# Altium schematic sheet Debug.SchDoc
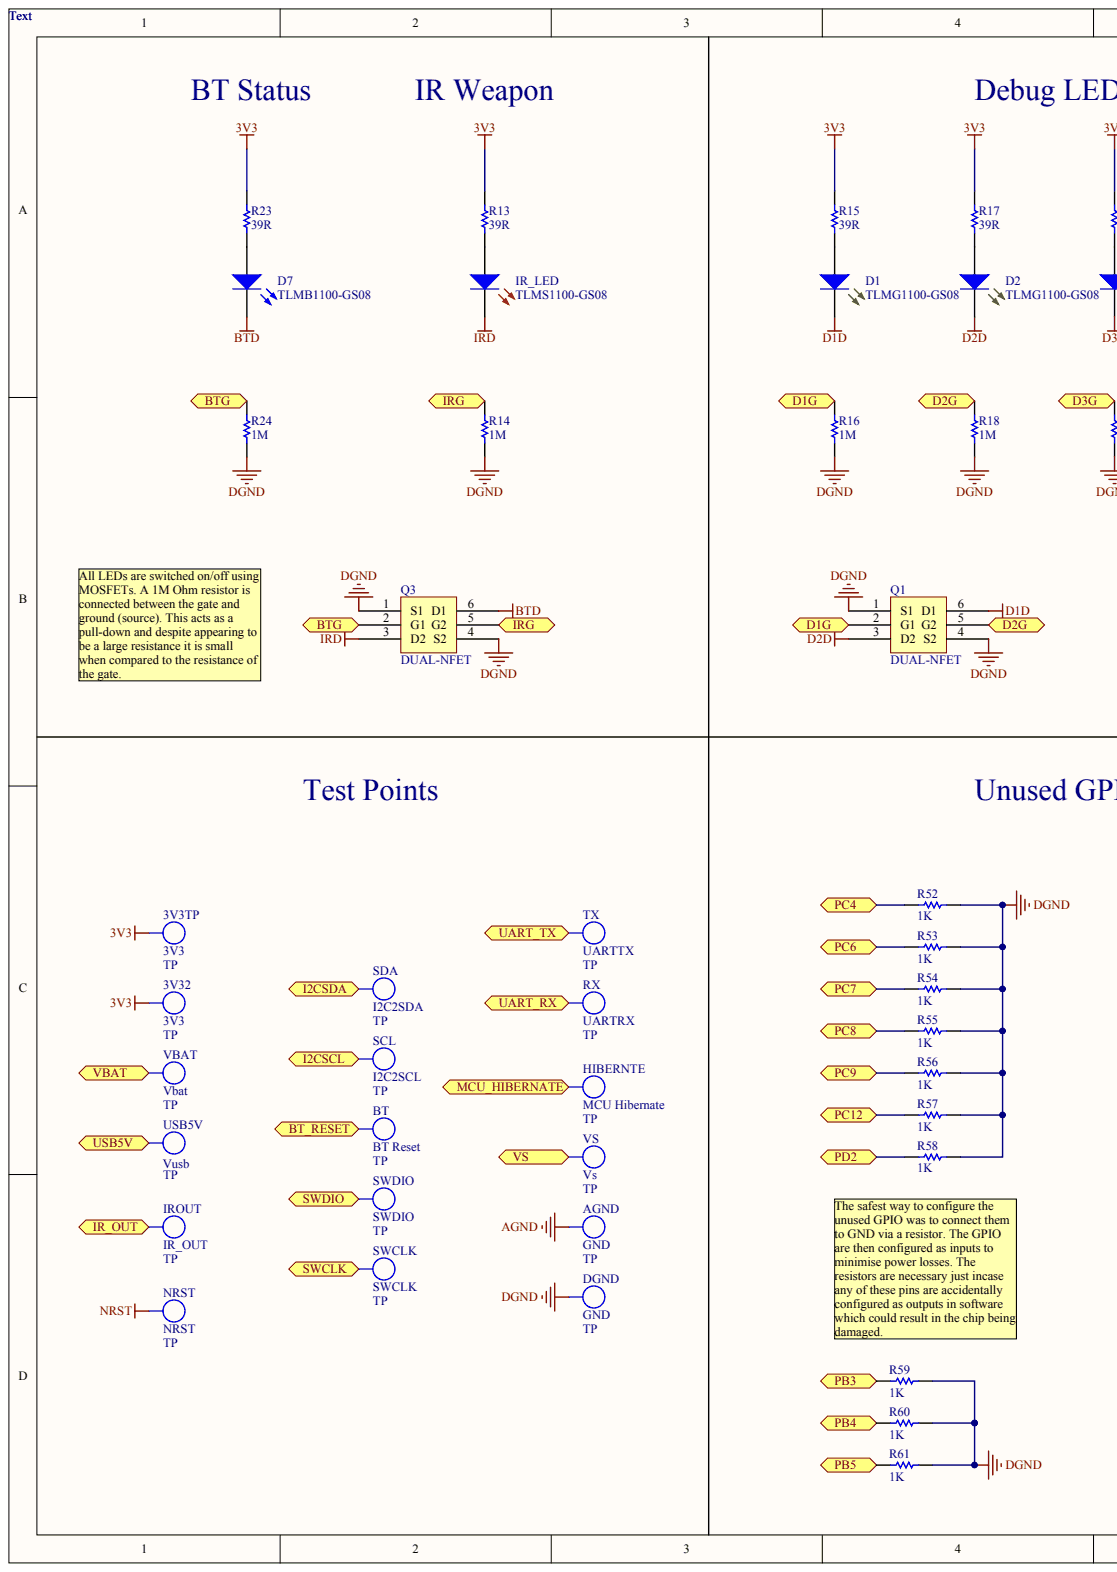
[diagram p0: Debug.SchDoc, left]
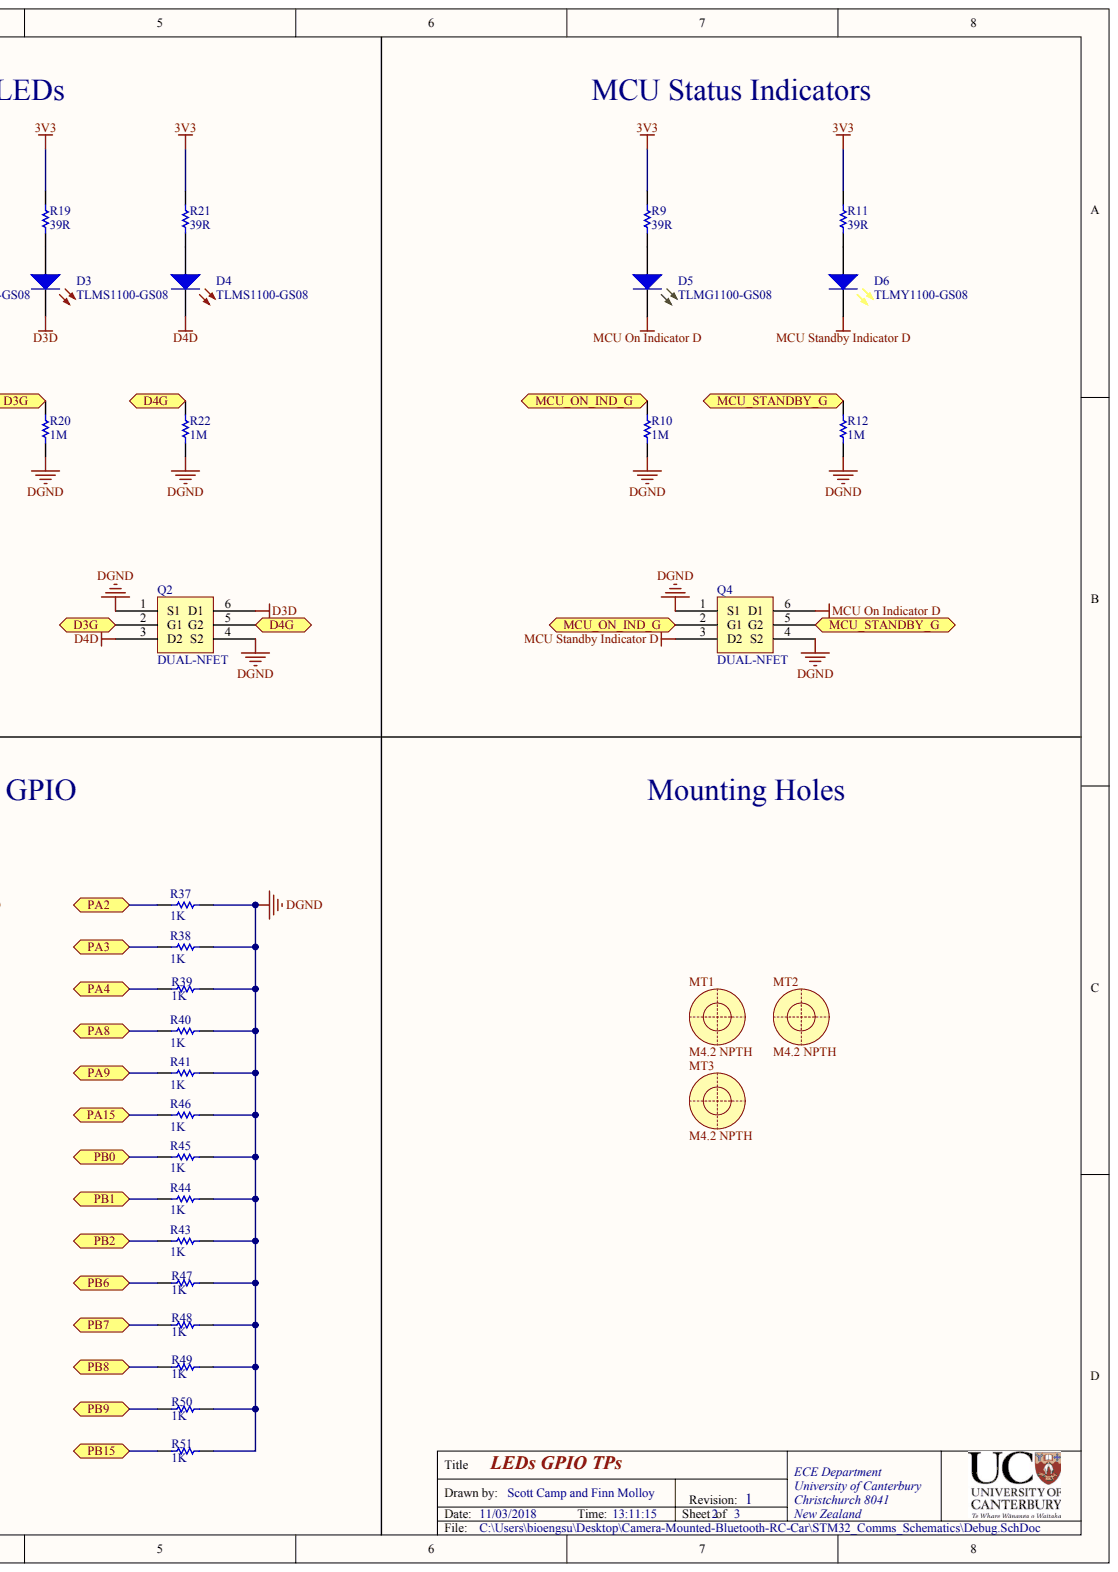
[diagram p1: Debug.SchDoc, right]

## Parts
3V3TP  3V3
3V32  3V3  value TP
AGND  GND  value TP
BT  BT Reset  value TP
D1  TLMG1100-GS08
D2  TLMG1100-GS08
D3  TLMS1100-GS08
D4  TLMS1100-GS08
D5  TLMG1100-GS08
D6  TLMY1100-GS08
D7  TLMB1100-GS08
DGND  GND  value TP
HIBERNTE  MCU Hibernate  value TP
IR_LED  TLMS1100-GS08
IROUT  IR_OUT  value TP
MT1  M4.2 NPTH
MT2  M4.2 NPTH
MT3  M4.2 NPTH
NRST  NRST  value TP
Q1  DUAL-NFET
Q2  DUAL-NFET
Q3  DUAL-NFET
Q4  DUAL-NFET
R9  39R
R10  1M
R11  39R
R12  1M
R13  39R
R14  1M
R15  39R
R16  1M
R17  39R
R18  1M
R19  39R
R20  1M
R21  39R
R22  1M
R23  39R
R24  1M
R37  1K
R38  1K
R39  1K
R40  1K
R41  1K
R43  1K
R44  1K
R45  1K
R46  1K
R47  1K
R48  1K
R49  1K
R50  1K
R51  1K
R52  1K
R53  1K
R54  1K
R55  1K
R56  1K
R57  1K
R58  1K
R59  1K
R60  1K
R61  1K
RX  UARTRX  value TP
SCL  I2C2SCL  value TP
SDA  I2C2SDA
SWCLK  SWCLK  value TP
SWDIO  SWDIO  value TP
TX  UARTTX
USB5V  Vusb  value TP
VBAT  Vbat  value TP
VS  Vs  value TP

## Ports
BT_RESET, BTG, D1G, D2G, D3G, D4G, I2CSCL, I2CSDA, IR_OUT, IRG, MCU_HIBERNATE, MCU_ON_IND_G, MCU_STANDBY_G, PA2, PA3, PA4, PA8, PA9, PA15, PB0, PB1, PB2, PB3, PB4, PB5, PB6, PB7, PB8, PB9, PB15, PC4, PC6, PC7, PC8, PC9, PC12, PD2, SWCLK, SWDIO, UART_RX, UART_TX, USB5V, VBAT, VS

## Nets
3V3: 3V3TP.1 3V32.1 R9.2 R11.2 R13.2 R15.2 R17.2 R19.2 R21.2 R23.2
AGND: AGND.1
BTD: D7.2 Q3.6(D1)
D1D: D1.2 Q1.6(D1)
D2D: D2.2 Q1.3(D2)
D3D: D3.2 Q2.6(D1)
D4D: D4.2 Q2.3(D2)
DGND: DGND.1 Q1.1(S1) Q1.4(S2) Q2.1(S1) Q2.4(S2) Q3.1(S1) Q3.4(S2) Q4.1(S1) Q4.4(S2) R10.1 R12.1 R14.1 R16.1 R18.1 R20.1 R22.1 R24.1 R37.1 R38.1 R39.1 R40.1 R41.1 R43.1 R44.1 R45.1 R46.1 R47.2 R48.2 R49.2 R50.2 R51.1 R52.1 R53.1 R54.1 R55.1 R56.1 R57.1 R58.1 R59.1 R60.1 R61.1
IRD: IR_LED.2 Q3.3(D2)
MCU ON INDICATOR D: D5.2 Q4.6(D1)
MCU STANDBY INDICATOR D: D6.2 Q4.3(D2)
NRST: NRST.1
BT_RESET: BT.1 ; port BT_RESET
BTG: Q3.2(G1) R24.2 ; port BTG
D1G: Q1.2(G1) R16.2 ; port D1G
D2G: Q1.5(G2) R18.2 ; port D2G
D3G: Q2.2(G1) R20.2 ; port D3G
D4G: Q2.5(G2) R22.2 ; port D4G
I2CSCL: SCL.1 ; port I2CSCL
I2CSDA: SDA.1 ; port I2CSDA
IR_OUT: IROUT.1 ; port IR_OUT
IRG: Q3.5(G2) R14.2 ; port IRG
MCU_HIBERNATE: HIBERNTE.1 ; port MCU_HIBERNATE
MCU_ON_IND_G: Q4.2(G1) R10.2 ; port MCU_ON_IND_G
MCU_STANDBY_G: Q4.5(G2) R12.2 ; port MCU_STANDBY_G
PA2: R37.2 ; port PA2
PA3: R38.2 ; port PA3
PA4: R39.2 ; port PA4
PA8: R40.2 ; port PA8
PA9: R41.2 ; port PA9
PA15: R46.2 ; port PA15
PB0: R45.2 ; port PB0
PB1: R44.2 ; port PB1
PB2: R43.2 ; port PB2
PB3: R59.2 ; port PB3
PB4: R60.2 ; port PB4
PB5: R61.2 ; port PB5
PB6: R47.1 ; port PB6
PB7: R48.1 ; port PB7
PB8: R49.1 ; port PB8
PB9: R50.1 ; port PB9
PB15: R51.2 ; port PB15
PC4: R52.2 ; port PC4
PC6: R53.2 ; port PC6
PC7: R54.2 ; port PC7
PC8: R55.2 ; port PC8
PC9: R56.2 ; port PC9
PC12: R57.2 ; port PC12
PD2: R58.2 ; port PD2
SWCLK: SWCLK.1 ; port SWCLK
SWDIO: SWDIO.1 ; port SWDIO
UART_RX: RX.1 ; port UART_RX
UART_TX: TX.1 ; port UART_TX
USB5V: USB5V.1 ; port USB5V
VBAT: VBAT.1 ; port VBAT
VS: VS.1 ; port VS
NetD1_1: D1.1 R15.1
NetD2_1: D2.1 R17.1
NetD3_1: D3.1 R19.1
NetD4_1: D4.1 R21.1
NetD5_1: D5.1 R9.1
NetD6_1: D6.1 R11.1
NetD7_1: D7.1 R23.1
NetIR_LED_1: IR_LED.1 R13.1

## Library data of the parts
3V3TP SDA TX = (no symbol name): Value: TP; Manufacturer PN: *; Manufacturer: *; Supplier 1: ECE Stock; Supplier Part Number 1: 5016KTR-ND; Storage Location: ProtoPlace
D1 D2 D5 = (no symbol name): Manufacturer: Vishay Semiconductor Opto Division; Color: Green; Millicandela Rating: 35mcd; Voltage - Forward (Vf) Typ: 2.1V; Viewing Angle: 160°; Wavelength - Peak: 572nm; Supplier 1: Farnell; Supplier 2: Digi-Key; Package / Case: 0603 (1608 Metric); Packaging: Cut Tape (CT); Size / Dimension: 1.60mm L x 0.80mm W; Pricing: 1=0.67, 10=0.525, 25=0.4376, 100=0.359, 250=0.29912, 500=0.24852, 1000=0.18408 (NZD); Supplier Part Number 1: 2293494; Supplier Part Number 2: 751-1165-1-ND; Manufacturer Part Number: TLMG1100-GS08
D3 D4 IR_LED = (no symbol name): Lens Style/Size: Rectangle with Flat Top, 1.6mm x 0.8mm; Millicandela Rating: 63mcd; Viewing Angle: 160°; Voltage - Forward (Vf) Typ: 2.1V; Wavelength - Dominant: 633nm; Supplier 1: Farnell; Supplier 2: Digi-Key; Package / Case: 0603 (1608 Metric); Size / Dimension: 1.60mm L x 0.80mm W; Pricing: 1=0.67, 10=0.525, 25=0.4376, 100=0.359, 250=0.29912, 500=0.24852, 1000=0.18408 (NZD); Supplier Part Number 1: 1440338; Supplier Part Number 2: 751-1182-1-ND; Manufacturer Part Number: TLMS1100-GS08
D6 = (no symbol name): Manufacturer: Vishay Semiconductor Opto Division; Lens Style/Size: Rectangle with Flat Top, 1.6mm x 0.8mm; Millicandela Rating: 80mcd; Viewing Angle: 160°; Voltage - Forward (Vf) Typ: 2.1V; Wavelength - Peak: 591nm; Supplier 1: Farnell; Supplier 2: Digi-Key; Package / Case: 0603 (1608 Metric); Packaging: Cut Tape (CT); Size / Dimension: 1.60mm L x 0.80mm W; Pricing: 1=0.67, 10=0.525, 25=0.4376, 100=0.359, 250=0.29912, 500=0.24852, 1000=0.18408 (NZD); Supplier Part Number 1: 2103887; Supplier Part Number 2: 751-1186-1-ND; Manufacturer Part Number: TLMY1100-GS08
D7 = (no symbol name): Manufacturer: Vishay Semiconductor Opto Division; Lens Style/Size: Rectangle with Flat Top, 1.6mm x 0.8mm; Millicandela Rating: 5mcd; Viewing Angle: 160°; Voltage - Forward (Vf) Typ: 3.9V; Wavelength - Peak: 428nm; Supplier 1: Farnell; Supplier 2: Digi-Key; Package / Case: 0603 (1608 Metric); Packaging: Cut Tape (CT); Size / Dimension: 1.60mm L x 0.80mm W; Pricing: 1=1.51, 10=1.341, 25=1.184, 100=1.0656, 250=0.92748, 500=0.82882, 1000=0.65121 (NZD); Supplier Part Number 1: 1328305; Supplier Part Number 2: 751-1156-1-ND; Manufacturer Part Number: TLMB1100-GS08
MT1-MT3 = (no symbol name): Manufacturer: Hi-Q; Manufacturer PN: 4NE Series M4 Clearance; price: 0; value: M4.2 NPTH
3V32 AGND BT DGND HIBERNTE IROUT NRST Q1-Q4 R9-R24 R37-R41 R43-R61 RX SCL SWCLK SWDIO USB5V VBAT VS = (no symbol name)
  3V32 AGND BT DGND HIBERNTE IROUT NRST RX SCL SWCLK SWDIO USB5V VBAT VS: Manufacturer PN: *; Manufacturer: *; Supplier 1: ECE Stock; Supplier Part Number 1: 5016KTR-ND; Storage Location: ProtoPlace
  R9 R11 R13 R15 R17 R19 R21 R23: Storage Location: ProtoPlace; Supplier: ECE Dept Stock; Value: 39R
  R10 R12 R14 R16 R18 R20 R22 R24: Storage Location: ProtoPlace  Red Pottel; Supplier: ECE Dept Stock; Value: 1M
  R37-R41 R43-R61: Storage Location: ProtoPlace; Supplier: ECE Dept Stock; Value: 1K
